# Altium schematic sheet Sheet1.SchDoc(Sheet1) (continued)
## Library data of the parts (continued)
R17 = ERJ-3GEYJ222V: RES SMD 2.2K OHM 1% 1/16W 0402; Manufacturer: Panasonic; Max Power Dissipation: 100mW; Resistance: 2.2kR; Temperature Coefficient: 200ppm/°C; Tolerance: 5%; Voltage Rating: 75V; Voltage Rating (DC): 50V; Case Code (Imperial): 0603; Case Code (Metric): 1608; Case/Package: 0603; Category: Chip SMD Resistors; Component Kind: Standard; ComponentLink10Description: https://datasheet.ciiva.com/28584/1678796-28584095.pdf?src-supplier=Element14; ComponentLink10URL: https://datasheet.ciiva.com/28584/1678796-28584095.pdf?src-supplier=Element14; ComponentLink1Description: https://datasheet.ciiva.com/11821/0900766b80f806dd-11821453.pdf?src-supplier=RSComponents; ComponentLink1URL: https://datasheet.ciiva.com/11821/0900766b80f806dd-11821453.pdf?src-supplier=RSComponents; ComponentLink2Description: https://datasheet.ciiva.com/34303/1988639-34303614.pdf?src-supplier=Jameco; ComponentLink2URL: https://datasheet.ciiva.com/34303/1988639-34303614.pdf?src-supplier=Jameco; ComponentLink3Description: https://datasheet.ciiva.com/16760/70255313-16760341.pdf?src-supplier=Allied+Electronics; ComponentLink3URL: https://datasheet.ciiva.com/16760/70255313-16760341.pdf?src-supplier=Allied+Electronics; ComponentLink4Description: https://datasheet.ciiva.com/9117/aoa0000ce1-9117127.pdf?src-supplier=Avnet; ComponentLink4URL: https://datasheet.ciiva.com/9117/aoa0000ce1-9117127.pdf?src-supplier=Avnet; ComponentLink5Description: https://datasheet.ciiva.com/46380/1500035-46380411.pdf?src-supplier=Element14; ComponentLink5URL: https://datasheet.ciiva.com/46380/1500035-46380411.pdf?src-supplier=Element14; ComponentLink6Description: https://datasheet.ciiva.com/16765/70255313-16765244.pdf?src-supplier=Allied+Electronics; ComponentLink6URL: https://datasheet.ciiva.com/16765/70255313-16765244.pdf?src-supplier=Allied+Electronics; ComponentLink7Description: https://datasheet.ciiva.com/16199/aoa0000ce1-16199902.pdf?src-supplier=Future+Electronics; ComponentLink7URL: https://datasheet.ciiva.com/16199/aoa0000ce1-16199902.pdf?src-supplier=Future+Electronics; ComponentLink8Description: https://datasheet.ciiva.com/16853/aoa0000ce1-16853407.pdf?src-supplier=Avnet+Europe; ComponentLink8URL: https://datasheet.ciiva.com/16853/aoa0000ce1-16853407.pdf?src-supplier=Avnet+Europe; ComponentLink9Description: https://datasheet.ciiva.com/22758/1500035-22758223.pdf?src-supplier=Farnell; ComponentLink9URL: https://datasheet.ciiva.com/22758/1500035-22758223.pdf?src-supplier=Farnell; Composition: Thick Film; Contact Plating: Tin; Depth: 0.8mm; Features: Automotive AEC-Q200; Height: 0.45mm; Lead Free: Lead Free; Length: 1.6mm; Library Name: MyLibrary.SchLib; Manufacturer Part Number: ERJ-3GEYJ222V; Max Operating Temperature: 155°C; Military Standard: Not; Min Operating Temperature: -55°C; Mount: Surface Mount; Number of Terminations: 2; Package Quantity: 1; Packaging: Tape and Reel; Power Rating: 100mW; Pricing 1: 1=0.10000, 10=0.03100, 100=0.01250, 1000=0.00562, 2500=0.00488 (USD); Radiation Hardening: No; REACH SVHC: No SVHC; RoHS: Yes; Stock 1: 3243658; Supplier 1: Digi-Key; Supplier Part Number 1: 311-2.20KLRCT-ND; Termination: SMD/SMT; Width: 0.8mm; Working Voltage: 75V
R24 R27-R29 = ERA-2AEB513X: RES SMD 51K OHM 0.1% 1/16W 0402; Manufacturer: Panasonic; Max Power Dissipation: 63mW; Resistance: 51kR; Temperature Coefficient: 25ppm/°C; Voltage Rating: 25V; Case Code (Imperial): 0402; Case Code (Metric): 1005; Case/Package: 0402; Category: Chip SMD Resistors; Component Kind: Standard; ComponentLink1Description: https://datasheet.ciiva.com/43470/1776116-43470503.pdf?src-supplier=Farnell; ComponentLink1URL: https://datasheet.ciiva.com/43470/1776116-43470503.pdf?src-supplier=Farnell; ComponentLink2Description: https://datasheet.ciiva.com/17209/aoa0000ce26-17209404.pdf?src-supplier=Avnet+Europe; ComponentLink2URL: https://datasheet.ciiva.com/17209/aoa0000ce26-17209404.pdf?src-supplier=Avnet+Europe; Composition: Thin Film,Metal Film; Contact Plating: Tin; Depth: 0.5mm; Height: 0.016inch; Lead Free: Lead Free; Length: 1mm; Library Name: MyLibrary.SchLib; Manufacturer Part Number: ERA-2AEB513X; Max Operating Temperature: 155°C; Military Standard: Not; Min Operating Temperature: -55°C; Mount: Surface Mount; Number of Terminations: 2; Package Quantity: 10000; Packaging: Tape and Reel; Power Rating: 63mW; Pricing 1: 1=0.41000, 10=0.29400, 100=0.11770, 1000=0.05802, 2500=0.05376, 5000=0.04864 (USD); Radiation Hardening: No; REACH SVHC: No SVHC; RoHS: Yes; Stock 1: 69289; Supplier 1: Digi-Key; Supplier Part Number 1: P51KDCCT-ND; Termination: SMD/SMT; Tolerance: 0.1%; Voltage Rating (DC): 25V; Width: 0.02inch
R26 = RC0603JR-0782KL: RES SMD 82K OHM 1% 1/16W 0402; Manufacturer: Yageo; Max Power Dissipation: 100mW; Resistance: 82kR; Temperature Coefficient: 100ppm/°C; Tolerance: 5%; Voltage Rating: 75V; Voltage Rating (DC): 50V; Case Code (Imperial): 0603; Case Code (Metric): 1608; Case/Package: 0603; Category: Chip SMD Resistors; Component Kind: Standard; ComponentLink1Description: https://datasheet.ciiva.com/2453/pyu-r-int-thick-7-2453028.pdf?src-supplier=Digi-Key; ComponentLink1URL: https://datasheet.ciiva.com/2453/pyu-r-int-thick-7-2453028.pdf?src-supplier=Digi-Key; ComponentLink2Description: https://datasheet.ciiva.com/2453/pyu-r-int-thick-7-2453029.pdf?src-supplier=Digi-Key; ComponentLink2URL: https://datasheet.ciiva.com/2453/pyu-r-int-thick-7-2453029.pdf?src-supplier=Digi-Key; ComponentLink3Description: https://datasheet.ciiva.com/2453/pyu-r-int-thick-7-2453030.pdf?src-supplier=Digi-Key; ComponentLink3URL: https://datasheet.ciiva.com/2453/pyu-r-int-thick-7-2453030.pdf?src-supplier=Digi-Key; ComponentLink4Description: https://datasheet.ciiva.com/6063/1868787-6063127.pdf?src-supplier=Farnell; ComponentLink4URL: https://datasheet.ciiva.com/6063/1868787-6063127.pdf?src-supplier=Farnell; ComponentLink5Description: https://datasheet.ciiva.com/7746/443971-7746539.pdf?src-supplier=Element14; ComponentLink5URL: https://datasheet.ciiva.com/7746/443971-7746539.pdf?src-supplier=Element14; ComponentLink6Description: https://datasheet.ciiva.com/7746/443971-7746540.pdf?src-supplier=Element14; ComponentLink6URL: https://datasheet.ciiva.com/7746/443971-7746540.pdf?src-supplier=Element14; Composition: Thick Film; Depth: 0.8mm; Features: Moisture Resistant; Height: 0.022inch; Lead Free: Lead Free; Length: 1.6mm; Library Name: MyLibrary.SchLib; Manufacturer Part Number: RC0603JR-0782KL; Max Operating Temperature: 155°C; Military Standard: Not; Min Operating Temperature: -55°C; Number of Pins: 2; Number of Terminations: 2; Package Quantity: 1; Packaging: Tape and Reel; Power Rating: 100mW; Pricing 1: 1=0.10000, 10=0.01800, 100=0.00740, 1000=0.00334, 2500=0.00290 (USD); REACH SVHC: No SVHC; RoHS: Yes; Stock 1: 264300; Supplier 1: Digi-Key; Supplier Part Number 1: A129650CT-ND; Termination: SMD/SMT; Weight: 7.1E-05oz; Width: 0.8mm
R32 = CPF0603B910KE1: RES SMD 910K OHM 5% 1/16W 0402; Manufacturer: TE Connectivity; Number of Resistors: 1; Power Rating: 63mW; Resistance: 910kR; Temperature Coefficient: 25ppm/°C; Tolerance: 0.1%; Voltage Rating: 50V; Case Code (Imperial): 0603; Case Code (Metric): 1608; Case/Package: 0603; Category: Chip SMD Resistors; Component Kind: Standard; ComponentLink1Description: https://datasheet.ciiva.com/28562/1723857-28562891.pdf?src-supplier=Element14; ComponentLink1URL: https://datasheet.ciiva.com/28562/1723857-28562891.pdf?src-supplier=Element14; ComponentLink2Description: https://datasheet.ciiva.com/22671/1723857-22671052.pdf?src-supplier=Element14; ComponentLink2URL: https://datasheet.ciiva.com/22671/1723857-22671052.pdf?src-supplier=Element14; Composition: Thin Film; ELV: Compliant; Library Name: MyLibrary.SchLib; Manufacturer Part Number: CPF0603B910KE1; Mount: Surface Mount; Number of Terminations: 2; Packaging: Tape and Reel; Pricing 1: 1=0.62000, 10=0.44600, 100=0.23210 (USD); REACH SVHC: No SVHC; RoHS: Yes; Stock 1: 8021; Supplier 1: Digi-Key; Supplier Part Number 1: YAG3319CT-ND; Termination: Solder; Voltage Rating (DC): 50V
R34 = CRGCQ0603F180K: RES SMD 180K OHM  1% 1/16W 0402; Manufacturer: TE Connectivity; Max Power Dissipation: 100mW; Resistance: 180kR; Temperature Coefficient: 100ppm/°C; Case Code (Imperial): 0603; Case Code (Metric): 1608; Case/Package: 0603; Component Kind: Standard; ComponentLink1Description: https://www.te.com/commerce/DocumentDelivery/DDEController?Action=srchrtrv&DocNm=1773204-3&DocType=DS&DocLang=English&src-supplier=Digi-Key; ComponentLink1URL: https://www.te.com/commerce/DocumentDelivery/DDEController?Action=srchrtrv&DocNm=1773204-3&DocType=DS&DocLang=English&src-supplier=Digi-Key; ComponentLink2Description: https://4donline.ihs.com/images/VipMasterIC/IC/TYEL/TYEL-S-A0004929575/TYEL-S-A0004929575-1.pdf?hkey=52A5661711E402568146F3353EA87419&src-supplier=Newark; ComponentLink2URL: https://4donline.ihs.com/images/VipMasterIC/IC/TYEL/TYEL-S-A0004929575/TYEL-S-A0004929575-1.pdf?hkey=52A5661711E402568146F3353EA87419&src-supplier=Newark; ComponentLink3Description: https://www.te.com/commerce/DocumentDelivery/DDEController?Action=srchrtrv&amp;DocNm=1773204-3&amp;DocType=DS&amp;DocLang=English&src-supplier=Digi-Key; ComponentLink3URL: https://www.te.com/commerce/DocumentDelivery/DDEController?Action=srchrtrv&amp;DocNm=1773204-3&amp;DocType=DS&amp;DocLang=English&src-supplier=Digi-Key; ComponentLink4Description: https://4donline.ihs.com/images/VipMasterIC/IC/TYEL/TYEL-S-A0004929575/TYEL-S-A0004929575-1.pdf?hkey=52A5661711E402568146F3353EA87419&src-supplier=Farnell; ComponentLink4URL: https://4donline.ihs.com/images/VipMasterIC/IC/TYEL/TYEL-S-A0004929575/TYEL-S-A0004929575-1.pdf?hkey=52A5661711E402568146F3353EA87419&src-supplier=Farnell; ComponentLink5Description: https://4donline.ihs.com/images/VipMasterIC/IC/TYEL/TYEL-S-A0004929575/TYEL-S-A0004929575-1.pdf?hkey=52A5661711E402568146F3353EA87419&src-supplier=Element14; ComponentLink5URL: https://4donline.ihs.com/images/VipMasterIC/IC/TYEL/TYEL-S-A0004929575/TYEL-S-A0004929575-1.pdf?hkey=52A5661711E402568146F3353EA87419&src-supplier=Element14; Composition: Thick Film; Features: Moisture Resistant,Automotive AEC-Q200; Library Name: MyLibrary.SchLib; Manufacturer Part Number: CRGCQ0603F180K; Max Operating Temperature: 155°C; Min Operating Temperature: -55°C; Number of Terminations: 2; Packaging: Cut Tape; Pricing 1: 1=0.10000, 10=0.02800, 100=0.01160, 1000=0.00519, 2500=0.00451 (USD); RoHS: No; Stock 1: 58064; Supplier 1: Digi-Key; Supplier Part Number 1: A129654CT-ND; Tolerance: 1%
R38-R41 = ERJ3EKF3300V: RES SMD 330 OHM 1% 1/16W 0402; Manufacturer: Panasonic; Max Power Dissipation: 100mW; Temperature Coefficient: 100ppm/°C; Voltage Rating: 75V; Case Code (Imperial): 0603; Case Code (Metric): 1608; Case/Package: 0603; Category: Chip SMD Resistors; Component Kind: Standard; ComponentLink1Description: https://datasheet.ciiva.com/7886/aoa0000ce2-7886729.pdf?src-supplier=Element14; ComponentLink1URL: https://datasheet.ciiva.com/7886/aoa0000ce2-7886729.pdf?src-supplier=Element14; ComponentLink2Description: https://datasheet.ciiva.com/48531/aoa0000ce2-48531001.pdf?src-supplier=Avnet; ComponentLink2URL: https://datasheet.ciiva.com/48531/aoa0000ce2-48531001.pdf?src-supplier=Avnet; ComponentLink3Description: https://datasheet.ciiva.com/22721/426256-22721099.pdf?src-supplier=Element14; ComponentLink3URL: https://datasheet.ciiva.com/22721/426256-22721099.pdf?src-supplier=Element14; ComponentLink4Description: https://datasheet.ciiva.com/46373/1678782-46373899.pdf?src-supplier=Element14; ComponentLink4URL: https://datasheet.ciiva.com/46373/1678782-46373899.pdf?src-supplier=Element14; ComponentLink5Description: https://datasheet.ciiva.com/9117/aoa0000ce2-9117132.pdf?src-supplier=Avnet; ComponentLink5URL: https://datasheet.ciiva.com/9117/aoa0000ce2-9117132.pdf?src-supplier=Avnet; ComponentLink6Description: https://datasheet.ciiva.com/46373/1678782-46373900.pdf?src-supplier=Element14; ComponentLink6URL: https://datasheet.ciiva.com/46373/1678782-46373900.pdf?src-supplier=Element14; Composition: Thick Film; Contact Plating: Tin; Depth: 0.8mm; Features: Automotive AEC-Q200; Height: 0.45mm; Lead Free: Lead Free; Length: 1.6mm; Library Name: MyLibrary.SchLib; Manufacturer Part Number: ERJ3EKF3300V; Max Operating Temperature: 155°C; Military Standard: Not; Min Operating Temperature: -55°C; Mount: Surface Mount; Number of Pins: 2; Number of Terminations: 2; Package Quantity: 5000; Packaging: Tape and Reel; Power Rating: 100mW; Pricing 1: 1=0.10000, 10=0.03400, 100=0.01370, 1000=0.00617, 2500=0.00536 (USD); Radiation Hardening: No; REACH SVHC: No SVHC; Resistance: 330R; RoHS: Yes; Stock 1: 1349878; Supplier 1: Digi-Key; Supplier Part Number 1: 311-330LRCT-ND; Termination: SMD/SMT; Tolerance: 1%; Voltage Rating (DC): 75V; Weight: 7.1E-05oz; Width: 0.8mm
R42 = ERJ-PB3D4702V: RES SMD 47K OHM 0.1% 1/16W 0402; Manufacturer: Panasonic; Max Power Dissipation: 200mW; Resistance: 47kR; Temperature Coefficient: 50ppm/°C; Tolerance: 0.5%; Case Code (Imperial): 0603; Case Code (Metric): 1608; Case/Package: 0603; Category: Chip SMD Resistors; Component Kind: Standard; ComponentLink1Description: https://industrial.panasonic.com/sa/system/files/data/download/catalog/id_fixed-resistors_e_2.pdf?src-supplier=Digi-Key; ComponentLink1URL: https://industrial.panasonic.com/sa/system/files/data/download/catalog/id_fixed-resistors_e_2.pdf?src-supplier=Digi-Key; Composition: Thick Film; Features: Automotive AEC-Q200; Height - Seated (Max): 0.022inch; Length: 0.063inch; Library Name: MyLibrary.SchLib; Manufacturer Part Number: ERJ-PB3D4702V; Max Operating Temperature: 155°C; Min Operating Temperature: -55°C; Number of Terminations: 2; Packaging: Tape and Reel; Pricing 1: 1=0.17000, 10=0.14300, 25=0.10440, 50=0.07560, 100=0.05610, 250=0.04304, 500=0.03390, 1000=0.02347 (USD); RoHS: Yes; Stock 1: 2857; Supplier 1: Digi-Key; Supplier Part Number 1: P47KDCCT-ND; Width: 0.032inch
R43 = CRCW0402768KFKED: RES SMD 768K OHM 1% 1/8W 0402 [1005 Metric] 50 V; Manufacturer: Vishay; Power Rating: 63mW; Resistance: 768kR; Temperature Coefficient: 100ppm/°C; Voltage Rating: 50V; Case Code (Imperial): 0402; Case Code (Metric): 1005; Case/Package: 0402; Category: Chip SMD Resistors; Component Kind: Standard; ComponentLink1Description: https://datasheet.ciiva.com/7168/1635184-7168445.pdf?src-supplier=Newark; ComponentLink1URL: https://datasheet.ciiva.com/7168/1635184-7168445.pdf?src-supplier=Newark; ComponentLink2Description: https://datasheet.ciiva.com/7670/1697337-7670814.pdf?src-supplier=Element14; ComponentLink2URL: https://datasheet.ciiva.com/7670/1697337-7670814.pdf?src-supplier=Element14; ComponentLink3Description: https://datasheet.ciiva.com/3256/dcrcwe3-3256805.pdf?src-supplier=Avnet; ComponentLink3URL: https://datasheet.ciiva.com/3256/dcrcwe3-3256805.pdf?src-supplier=Avnet; ComponentLink4Description: https://datasheet.ciiva.com/3256/dcrcwe3-3256806.pdf?src-supplier=Avnet; ComponentLink4URL: https://datasheet.ciiva.com/3256/dcrcwe3-3256806.pdf?src-supplier=Avnet; ComponentLink5Description: https://datasheet.ciiva.com/5549/dcrcwe3-109170-5549224.pdf?src-supplier=Mouser; ComponentLink5URL: https://datasheet.ciiva.com/5549/dcrcwe3-109170-5549224.pdf?src-supplier=Mouser; ComponentLink6Description: https://datasheet.ciiva.com/22705/1697337-22705944.pdf?src-supplier=Element14; ComponentLink6URL: https://datasheet.ciiva.com/22705/1697337-22705944.pdf?src-supplier=Element14; ComponentLink7Description: https://datasheet.ciiva.com/7670/1697337-7670813.pdf?src-supplier=Element14; ComponentLink7URL: https://datasheet.ciiva.com/7670/1697337-7670813.pdf?src-supplier=Element14; Composition: Thick Film; Contact Plating: Tin; Depth: 0.5mm; Height: 0.35mm; Lead Free: Lead Free; Length: 1mm; Library Name: MyLibrary.SchLib; Manufacturer Part Number: CRCW0402768KFKED; Max Operating Temperature: 155°C; Military Standard: Not; Min Operating Temperature: -55°C; Number of Pins: 2; Package Quantity: 10000; Packaging: Tape and Reel; Pricing 1: 1=0.10000, 10=0.03500, 100=0.01410, 1000=0.00633, 2500=0.00550, 5000=0.00454 (USD); Radiation Hardening: No; REACH SVHC: Unknown; RoHS: Yes; Stock 1: 10009; Supplier 1: Digi-Key; Supplier Part Number 1: 541-768KLCT-ND; Tolerance: 1%; Voltage Rating (DC): 50V; Weight: 2.3E-05oz; Width: 0.5mm
RT1 = RL0503-5820-97-MS: THERMISTOR NTC 10KOHM BEAD; Manufacturer: Amphenol; Accuracy: 1%; Resistance: 10kR; Tolerance: 2%; Case/Package: Radial; Category: Thermistors; Component Kind: Standard; ComponentLink1Description: https://datasheet.ciiva.com/29856/aas-920-574a-thermometrics-ntc-type-ms-032114-web-29856981.pdf?src-supplier=Digi-Key; ComponentLink1URL: https://datasheet.ciiva.com/29856/aas-920-574a-thermometrics-ntc-type-ms-032114-web-29856981.pdf?src-supplier=Digi-Key; ComponentLink2Description: https://datasheet.ciiva.com/46652/70181330-46652421.pdf?src-supplier=Allied+Electronics; ComponentLink2URL: https://datasheet.ciiva.com/46652/70181330-46652421.pdf?src-supplier=Allied+Electronics; Diameter: 2.41mm; Lead Free: Lead Free; Lead Pitch: 5.08mm; Length: 28.7mm; Library Name: MyLibrary.SchLib; Manufacturer Part Number: RL0503-5820-97-MS; Max Operating Temperature: 150°C; Min Operating Temperature: -50°C; Mount: Free Hanging,PCB; Number of Pins: 2; Package Quantity: 500; Packaging: Bulk; Pricing 1: 1=2.02000, 5=1.91400, 10=1.64100, 25=1.31280, 50=1.20340, 100=1.09400, 500=0.95726, 1000=0.84785, 5000=0.79315 (USD); REACH SVHC: No SVHC; RoHS: Yes; Stock 1: 41085; Supplier 1: Digi-Key; Supplier Part Number 1: KC003T-ND; Termination: Radial; Width: 6.35mm
SW1 = EVQ-PF206K: SWITCH TACTILE SPST-NO 0.02A 15V; Manufacturer: Panasonic; Actuator Length: 5.85mm; Actuator Type: Standard; Contact Current Rating: 20mA; Contact Resistance: 50mR; Electrical Life: 100000Cycles; Illumination Type: Non-Illuminated,Illuminated; Operating Force: 1.6N; Switch Function: Off-Mom; Switch Travel: 0.25mm; Throw Configuration: SPST; Category: Resistors; Component Kind: Standard; ComponentLink1Description: https://datasheet.ciiva.com/25603/0900766b810ad1ef-25603886.pdf?src-supplier=RSComponents; ComponentLink1URL: https://datasheet.ciiva.com/25603/0900766b810ad1ef-25603886.pdf?src-supplier=RSComponents; ComponentLink2Description: https://datasheet.ciiva.com/30140/29atk0000ce32-30140741.pdf?src-supplier=Onlinecomponents.com; ComponentLink2URL: https://datasheet.ciiva.com/30140/29atk0000ce32-30140741.pdf?src-supplier=Onlinecomponents.com; ComponentLink3Description: https://datasheet.ciiva.com/2899/atk0000ce32-2899695.pdf?src-supplier=Digi-Key; ComponentLink3URL: https://datasheet.ciiva.com/2899/atk0000ce32-2899695.pdf?src-supplier=Digi-Key; ComponentLink4Description: https://datasheet.ciiva.com/17040/70270713-17040151.pdf?src-supplier=Allied+Electronics; ComponentLink4URL: https://datasheet.ciiva.com/17040/70270713-17040151.pdf?src-supplier=Allied+Electronics; ComponentLink5Description: https://datasheet.ciiva.com/8165/atk0000ce32-8165130.pdf?src-supplier=Avnet; ComponentLink5URL: https://datasheet.ciiva.com/8165/atk0000ce32-8165130.pdf?src-supplier=Avnet; Contact Plating: Silver,Gold; Current Rating: 20mA; Depth: 9.85mm; Height: 7.1mm; Lead Free: Lead Free; Length: 7.5mm; Library Name: MyLibrary.SchLib; Manufacturer Part Number: EVQ-PF206K; Max Current Rating: 20mA; Max Operating Temperature: 70°C; Max Voltage Rating (DC): 15V; Mechanical Life: 100000Cycles; Min Operating Temperature: -20°C; Mount: Through Hole; Orientation: Right Angle; Package Quantity: 500; Packaging: Bulk; Pricing 1: 500=0.09850, 1000=0.09692, 2000=0.09456, 3000=0.09298, 4000=0.09141 (USD); Radiation Hardening: No; REACH SVHC: No SVHC; RoHS: Yes; Stock 1: 1000; Supplier 1: Avnet; Supplier Part Number 1: EVQ-PF206K; Termination: PC Pins; Voltage Rating (DC): 15V; Width: 7.1mm
U1 U2 = LC05111C02MTTTG: BATT PROTECTION LI-ION 6WDFN; Manufacturer: ON Semiconductor; Fault Protection: Over Current; Max Power Dissipation: 350mW; Number of Cells: 1; Operating Supply Current: 3uA; Operating Supply Voltage: 4.5V; Category: Battery Management; Component Kind: Standard; ComponentLink1Description: http://www.mouser.com/ds/2/308/LC05111CMT-D-522471.pdf?src-supplier=Mouser; ComponentLink1URL: http://www.mouser.com/ds/2/308/LC05111CMT-D-522471.pdf?src-supplier=Mouser; ComponentLink2Description: http://www.alliedelec.com/images/products/datasheets/bm/ON_SEMICONDUCTOR/70547338.pdf?src-supplier=Allied+Electronics; ComponentLink2URL: http://www.alliedelec.com/images/products/datasheets/bm/ON_SEMICONDUCTOR/70547338.pdf?src-supplier=Allied+Electronics; ComponentLink3Description: http://www.farnell.com/datasheets/2255349.pdf?src-supplier=Farnell; ComponentLink3URL: http://www.farnell.com/datasheets/2255349.pdf?src-supplier=Farnell; ComponentLink4Description: http://www.onsemi.com/pub/Collateral/ENA2338-D.PDF?src-supplier=Avnet; ComponentLink4URL: http://www.onsemi.com/pub/Collateral/ENA2338-D.PDF?src-supplier=Avnet; ComponentLink5Description: http://www.onsemi.com/pub/Collateral/LC05111CMT-D.PDF?src-supplier=Digi-Key; ComponentLink5URL: http://www.onsemi.com/pub/Collateral/LC05111CMT-D.PDF?src-supplier=Digi-Key; Height: 0.8mm; Lead Free: Lead Free; Length: 4mm; Library Name: MyLibrary.SchLib; Manufacturer Part Number: LC05111C02MTTTG; Max Operating Temperature: 85°C; Min Operating Temperature: -40°C; Mount: Surface Mount; Number of Pins: 6; Package Quantity: 4000; Packaging: Tape and Reel; Pricing 1: 1=1.16000, 10=1.03300, 25=0.98000, 100=0.75250, 250=0.66500, 500=0.63000, 1000=0.49000 (USD); Radiation Hardening: No; RoHS: Yes; Stock 1: 2451; Supplier 1: Digi-Key; Supplier Part Number 1: LC05111C02MTTTGOSCT-ND; Width: 2.6mm
U3 = LC709511FQD-A00TWG: Power Bank Controller, USB Type-C & Quick Charge™ 3.0, for 1-Cell Li-Ion and Li-Poly Battery; Manufacturer: ON Semiconductor; Category: Battery Management; Component Kind: Standard; ComponentLink1Description: http://www.onsemi.com/pub/Collateral/LC709511F-D.PDF?src-supplier=Digi-Key; ComponentLink1URL: http://www.onsemi.com/pub/Collateral/LC709511F-D.PDF?src-supplier=Digi-Key; Library Name: MyLibrary.SchLib; Manufacturer Part Number: LC709511FQD-A00TWG; Pricing 1: 3000=3.45600 (USD); RoHS: No; Supplier 1: Digi-Key; Supplier Part Number 1: LC709511FQD-A00TWG-ND
U4 = TPS61022RWUR: boost converter  8-A with 0.5-V ultra-low input voltage 7-VQFN-HR -40 to 125; Manufacturer: Texas Instruments; Category: Voltage Regulators - Switching; Component Kind: Standard; Library Name: MyLibrary.SchLib; Manufacturer Part Number: TPS61022RWUR; Pricing 1: 3000=0.73370, 6000=0.70680, 9000=0.68350, 3000=0.76080, 30000=0.75270, 300000=0.70450, 1500000=0.67760, 3000000=0.67730 (USD); RoHS: No; Stock 1: 3000; Supplier 1: Arrow Electronics; Supplier Part Number 1: TPS61022RWUR
